# Altium schematic sheet GD32F103RCT6.SchDoc(GD32F103RCT6)
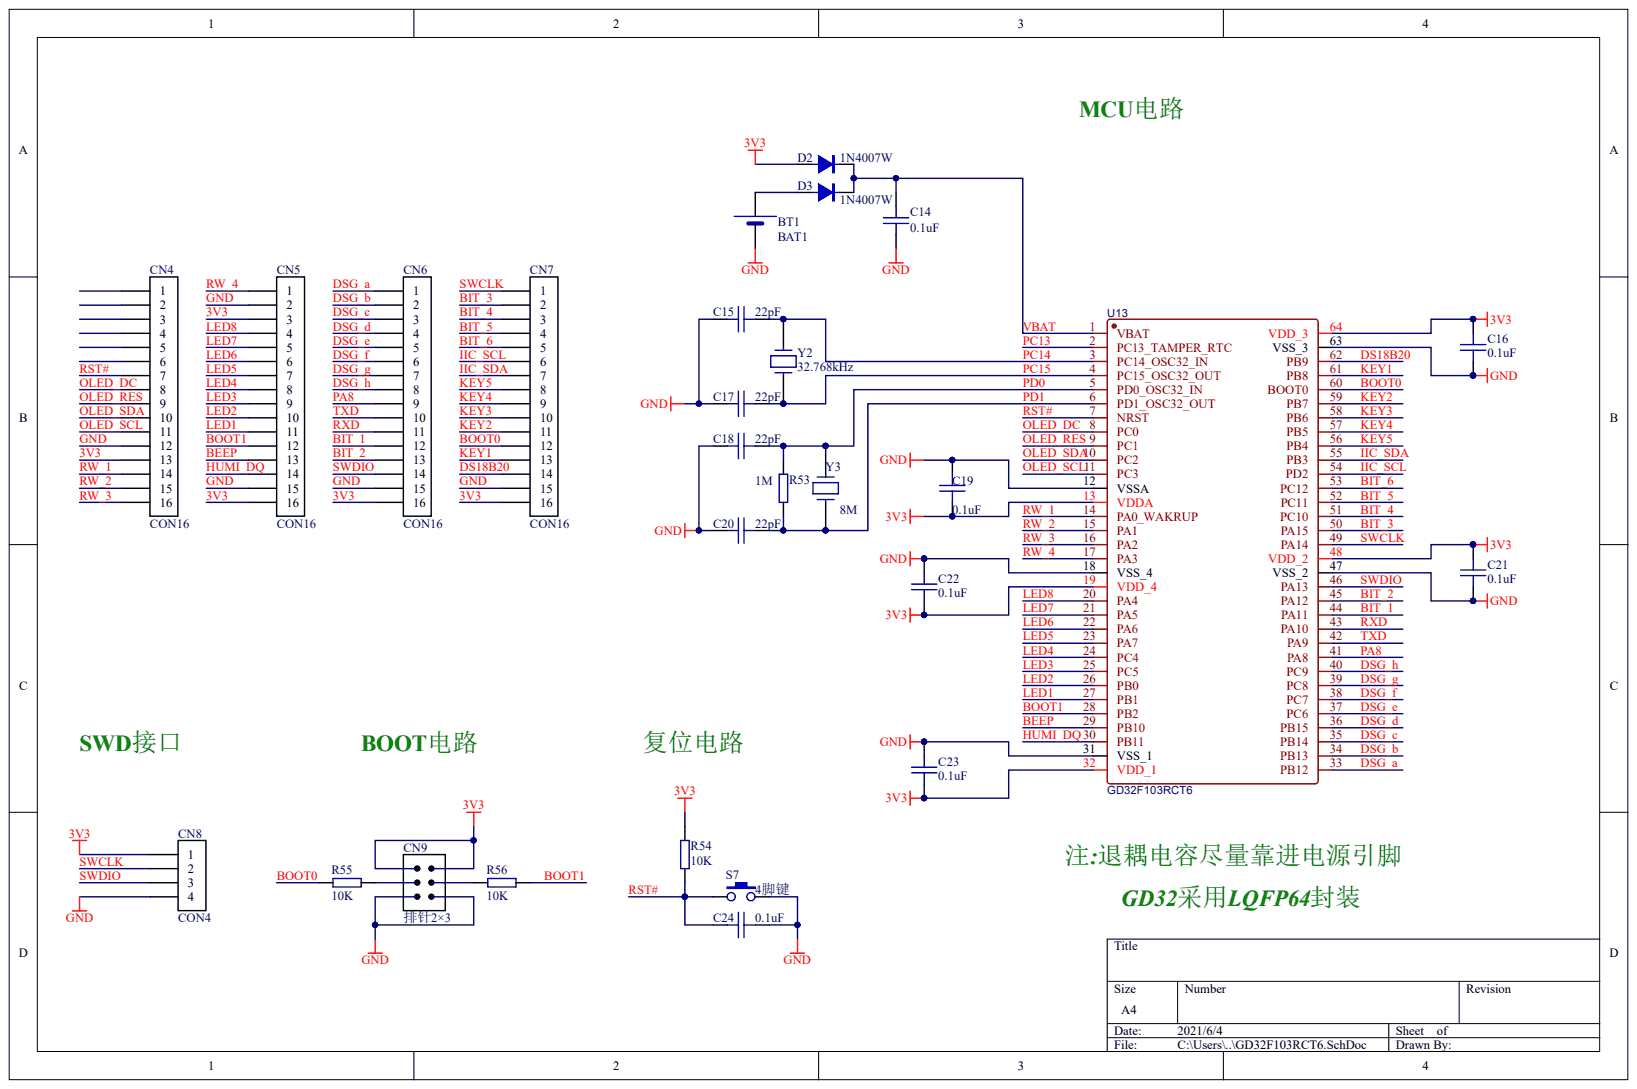
[diagram p0: GD32F103RCT6.SchDoc]

## Parts
BT1  BAT1  [CR1220(SMT)]
C14  0.1uF  [0805C]
C15  22pF  [0805C]
C16  0.1uF  [0805C]
C17  22pF  [0805C]
C18  22pF  [0805C]
C19  0.1uF  [0805C]
C20  22pF  [0805C]
C21  0.1uF  [0805C]
C22  0.1uF  [0805C]
C23  0.1uF  [0805C]
C24  0.1uF  [0805C]
CN4  CON16  [SIP-16(2.54)]
CN5  CON16  [SIP-16(2.54)]
CN6  CON16  [SIP-16(2.54)]
CN7  CON16  [SIP-16(2.54)]
CN8  CON4  [SIP-4(2.54)]
CN9  排针2×3  [IDC-6(2.54)]
D2  1N4007W  [DO-214AC]
D3  1N4007W  [DO-214AC]
R53  1M  [0805R]
R54  10K  [0805R]
R55  10K  [0805R]
R56  10K  [0805R]
S7  4脚键  [KEY4x4]
U13  GD32F103RCT6  [LQFP-64_L10.0-W10.0-P0.50-LS12.0-BL]
Y2  32.768kHz  [XTAL(Φ2×6)]
Y3  8M  [XTAL(HC-49)]

## Nets
3V3: C16.1 C19.1 C21.1 C22.1 C23.1 CN4.13 CN5.3 CN5.16 CN6.16 CN7.16 CN8.1 CN9.1 CN9.2 D2.1 R54.2 U13.13(VDDA) U13.19(VDD_4) U13.32(VDD_1) U13.48(VDD_2) U13.64(VDD_3)
BEEP: CN5.13 U13.29(PB10)
BIT_1: CN6.12 U13.44(PA11)
BIT_2: CN6.13 U13.45(PA12)
BIT_3: CN7.2 U13.50(PA15)
BIT_4: CN7.3 U13.51(PC10)
BIT_5: CN7.4 U13.52(PC11)
BIT_6: CN7.5 U13.53(PC12)
BOOT0: CN7.12 R55.1 U13.60(BOOT0)
BOOT1: CN5.12 R56.2 U13.28(PB2)
DS18B20: CN7.14 U13.62(PB9)
DSG_A: CN6.1 U13.33(PB12)
DSG_B: CN6.2 U13.34(PB13)
DSG_C: CN6.3 U13.35(PB14)
DSG_D: CN6.4 U13.36(PB15)
DSG_E: CN6.5 U13.37(PC6)
DSG_F: CN6.6 U13.38(PC7)
DSG_G: CN6.7 U13.39(PC8)
DSG_H: CN6.8 U13.40(PC9)
GND: BT1.2 C14.1 C15.1 C16.2 C17.1 C18.1 C19.2 C20.1 C21.2 C22.2 C23.2 C24.2 CN4.12 CN5.2 CN5.15 CN6.15 CN7.15 CN8.4 CN9.5 CN9.6 S7.2 U13.12(VSSA) U13.18(VSS_4) U13.31(VSS_1) U13.47(VSS_2) U13.63(VSS_3)
HUMI_DQ: CN5.14 U13.30(PB11)
IIC_SCL: CN7.6 U13.54(PD2)
IIC_SDA: CN7.7 U13.55(PB3)
KEY1: CN7.13 U13.61(PB8)
KEY2: CN7.11 U13.59(PB7)
KEY3: CN7.10 U13.58(PB6)
KEY4: CN7.9 U13.57(PB5)
KEY5: CN7.8 U13.56(PB4)
LED1: CN5.11 U13.27(PB1)
LED2: CN5.10 U13.26(PB0)
LED3: CN5.9 U13.25(PC5)
LED4: CN5.8 U13.24(PC4)
LED5: CN5.7 U13.23(PA7)
LED6: CN5.6 U13.22(PA6)
LED7: CN5.5 U13.21(PA5)
LED8: CN5.4 U13.20(PA4)
OLED_DC: CN4.8 U13.8(PC0)
OLED_RES: CN4.9 U13.9(PC1)
OLED_SCL: CN4.11 U13.11(PC3)
OLED_SDA: CN4.10 U13.10(PC2)
PA8: CN6.9 U13.41(PA8)
PC13: U13.2(PC13_TAMPER_RTC)
PC14: C15.2 U13.3(PC14_OSC32_IN) Y2.2
PC15: C17.2 U13.4(PC15_OSC32_OUT) Y2.1
PD0: C18.2 R53.1 U13.5(PD0_OSC32_IN) Y3.1
PD1: C20.2 R53.2 U13.6(PD1_OSC32_OUT) Y3.2
RST#: C24.1 CN4.7 R54.1 S7.1 U13.7(NRST)
RW_1: CN4.14 U13.14(PA0_WAKRUP)
RW_2: CN4.15 U13.15(PA1)
RW_3: CN4.16 U13.16(PA2)
RW_4: CN5.1 U13.17(PA3)
RXD: CN6.11 U13.43(PA10)
SWCLK: CN7.1 CN8.2 U13.49(PA14)
SWDIO: CN6.14 CN8.3 U13.46(PA13)
TXD: CN6.10 U13.42(PA9)
VBAT: C14.2 D2.2 D3.2 U13.1(VBAT)
NetBT1_1: BT1.1 D3.1
NetCN9_3: CN9.3 R55.2
NetCN9_4: CN9.4 R56.1

Not connected: CN4 1 2 3 4 5 6

## Library data of the parts
C14-C24 = 0805电容: Component Kind: Standard
  C14 C16-C24: Library Name: 电容Capacitor.SchLib
  C15: Library Name: D:\AD14\Library/常用PCB库.PcbLib
D2 D3 = 1N4007W: 1A整流二极管; Component Kind: Standard; Library Name: 二极管Diode.SchLib
R53-R56 = 0805电阻: Component Kind: Standard; Library Name: 电阻Resistor.SchLib
S7 = 2脚键: Component Kind: Standard; Library Name: 按键开关Switch.SchLib
U13 = GD32F103RCT6: BOM_Manufacturer: GigaDevice; subpart_no: 2; BOM_JLCPCB Part Class: Extended Part; BOM_Manufacturer Part: GD32F103RCT6; BOM_Supplier: LCSC; BOM_Supplier Part: C80687; Component Kind: Standard; Library Name: GD32F03RCT6.SCHLIB; spicePre: U; spiceSymbolName: GD32F103RCT6
Y2 Y3 = XTAL(HC-49): Component Kind: Standard; Library Name: 时钟振荡日历OSC-RTC.SchLib
BT1 = BAT1: Component Kind: Standard; Library Name: 电池及管理Battery.SchLib
CN4-CN7 = CON16: Component Kind: Standard; Library Name: 连接器Connector.SchLib
CN8 = CON4: Component Kind: Standard; Library Name: 连接器Connector.SchLib
CN9 = 排针2×3: Component Kind: Standard; Library Name: 连接器Connector.SchLib
